# Altium schematic sheet 04_STM32_MCU.SchDoc(04_STM32_MCU)
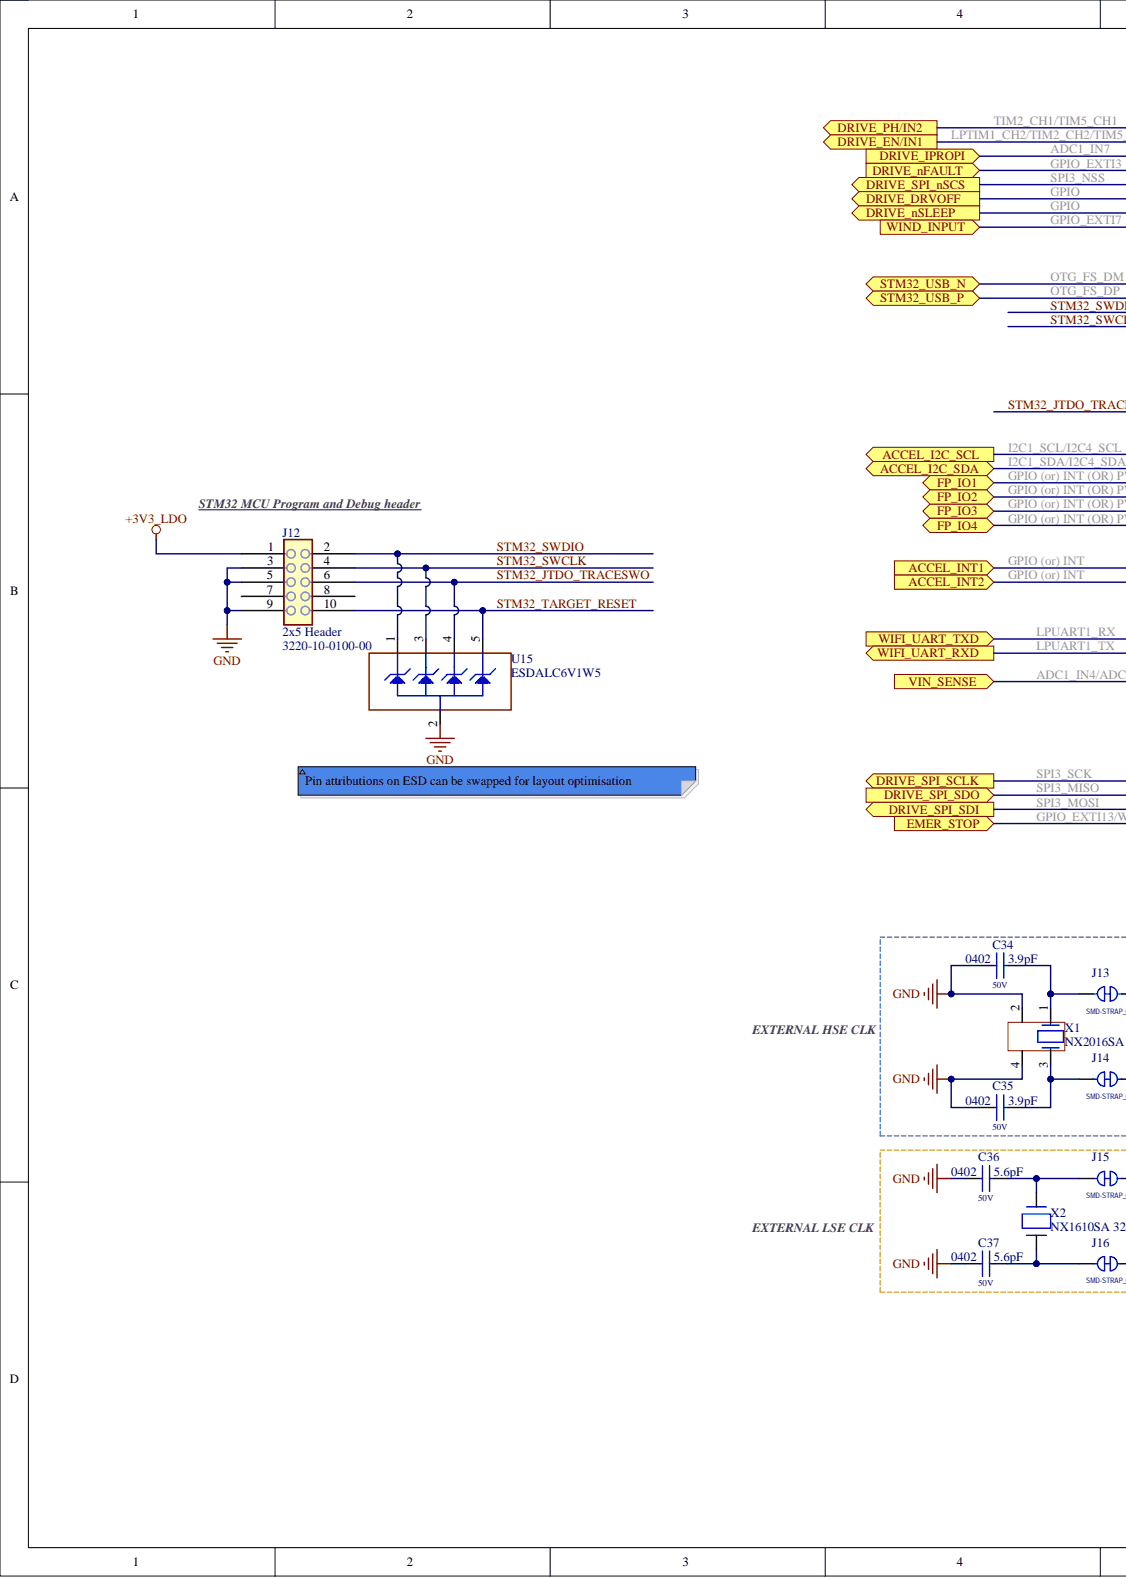
[diagram p0: 04_STM32_MCU.SchDoc, left]
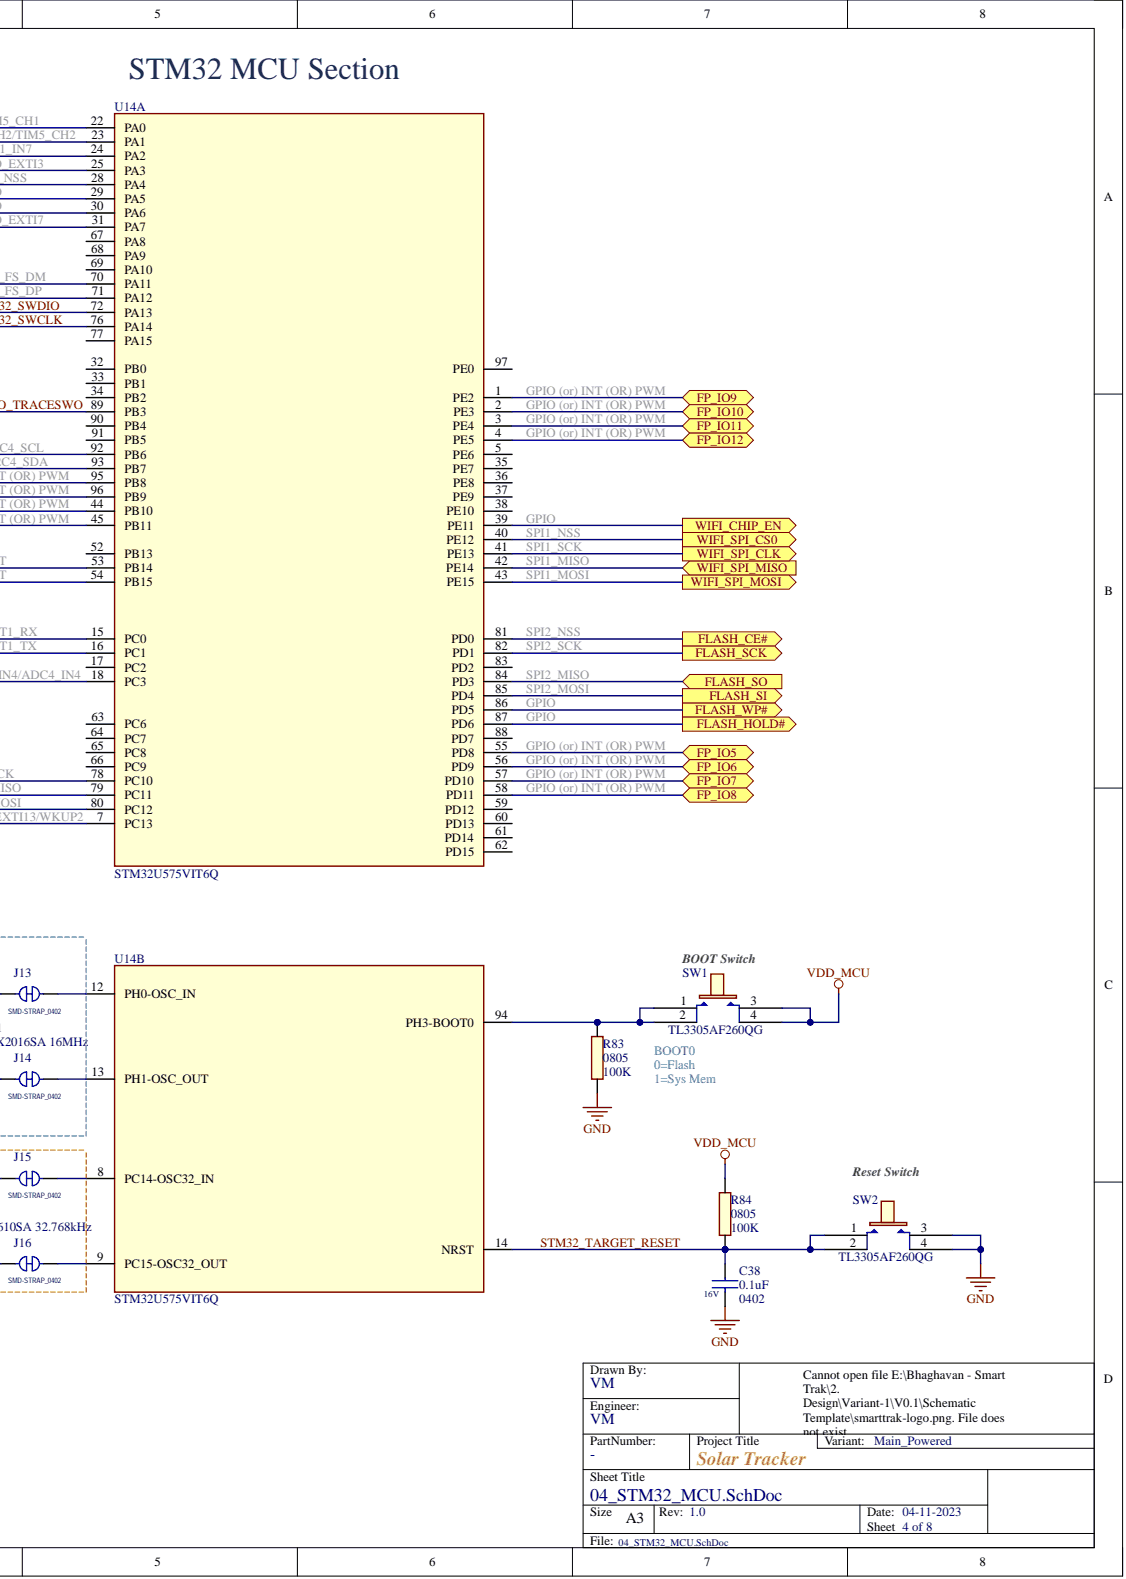
[diagram p1: 04_STM32_MCU.SchDoc, right]

## Parts
C34  value 3.9pF  Note: 0402  Voltage - Rated: 50V  [C0402]  (hidden comment: CAP_3.9pF_0402_50V)
C35  value 3.9pF  Note: 0402  Voltage - Rated: 50V  [C0402]  (hidden comment: CAP_3.9pF_0402_50V)
C36  value 5.6pF  Note: 0402  Voltage - Rated: 50V  [C0402]  (hidden comment: CAP_5.6pF_0402_50V)
C37  value 5.6pF  Note: 0402  Voltage - Rated: 50V  [C0402]  (hidden comment: CAP_5.6pF_0402_50V)
C38  value 0.1uF  Note: 0402  Voltage - Rated: 16V  [C0402]  (hidden comment: CAP_0.1uF_0402_16V)
J12  value 3220-10-0100-00  Note: 2x5 Header  [CNC_3220-10-0100-00]  (hidden comment: SWD_HEADER_2x5_TH)
J13  SMD-STRAP_0402  [SMD-STRAP_0402]
J14  SMD-STRAP_0402  [SMD-STRAP_0402]
J15  SMD-STRAP_0402  [SMD-STRAP_0402]
J16  SMD-STRAP_0402  [SMD-STRAP_0402]
R83  value 100K  Note: 0805  [R0805]  (hidden comment: RES_100K_0805)
R84  value 100K  Note: 0805  [R0805]  (hidden comment: RES_100K_0805)
SW1  value TL3305AF260QG  MPN: TL3305AF260QG  [SW_TL3305AF260QG]  (hidden comment: PUSHBUTTON_TL3305AF260QG)
SW2  value TL3305AF260QG  MPN: TL3305AF260QG  [SW_TL3305AF260QG]  (hidden comment: PUSHBUTTON_TL3305AF260QG)
U14  STM32U575VIT6Q  [QFP50P1600X1600X160-100N]  (parts A,B)
U15  value ESDALC6V1W5  MPN: ESDALC6V1W5  [SOT65P210X110-5N]  (hidden comment: TVS_ESDALC6V1W5)
X1  value NX2016SA 16MHz  [XTAL200X160X50-4N]  (hidden comment: XTAL_4_PINS)
X2  value NX1610SA 32.768kHz  [XTAL160X100X50-2N]  (hidden comment: XTAL_2PINS_32.768KHz)

## Ports
ACCEL_I2C_SCL, ACCEL_I2C_SDA, ACCEL_INT1, ACCEL_INT2, DRIVE_DRVOFF, DRIVE_EN/IN1, DRIVE_IPROPI, DRIVE_nFAULT, DRIVE_nSLEEP, DRIVE_PH/IN2, DRIVE_SPI_nSCS, DRIVE_SPI_SCLK, DRIVE_SPI_SDI, DRIVE_SPI_SDO, EMER_STOP, FLASH_CE#, FLASH_HOLD#, FLASH_SCK, FLASH_SI, FLASH_SO, FLASH_WP#, FP_IO1, FP_IO2, FP_IO3, FP_IO4, FP_IO5, FP_IO6, FP_IO7, FP_IO8, FP_IO9, FP_IO10, FP_IO11, FP_IO12, STM32_USB_N, STM32_USB_P, VIN_SENSE, WIFI_CHIP_EN, WIFI_SPI_CLK, WIFI_SPI_CS0, WIFI_SPI_MISO, WIFI_SPI_MOSI, WIFI_UART_RXD, WIFI_UART_TXD, WIND_INPUT

## Nets
+3V3_LDO: J12.1
GND: C34.1 C35.1 C36.1 C37.1 C38.2 J12.3 J12.5 J12.9 R83.1 SW2.3 SW2.4 U15.2 X1.2 X1.4
VDD_MCU: R84.2 SW1.3 SW1.4
ACCEL_I2C_SCL: U14.92(PB6) ; port ACCEL_I2C_SCL
ACCEL_I2C_SDA: U14.93(PB7) ; port ACCEL_I2C_SDA
ACCEL_INT1: U14.53(PB14) ; port ACCEL_INT1
ACCEL_INT2: U14.54(PB15) ; port ACCEL_INT2
DRIVE_DRVOFF: U14.29(PA5) ; port DRIVE_DRVOFF
DRIVE_EN/IN1: U14.23(PA1) ; port DRIVE_EN/IN1
DRIVE_IPROPI: U14.24(PA2) ; port DRIVE_IPROPI
DRIVE_nFAULT: U14.25(PA3) ; port DRIVE_nFAULT
DRIVE_nSLEEP: U14.30(PA6) ; port DRIVE_nSLEEP
DRIVE_PH/IN2: U14.22(PA0) ; port DRIVE_PH/IN2
DRIVE_SPI_nSCS: U14.28(PA4) ; port DRIVE_SPI_nSCS
DRIVE_SPI_SCLK: U14.78(PC10) ; port DRIVE_SPI_SCLK
DRIVE_SPI_SDI: U14.80(PC12) ; port DRIVE_SPI_SDI
DRIVE_SPI_SDO: U14.79(PC11) ; port DRIVE_SPI_SDO
EMER_STOP: U14.7(PC13) ; port EMER_STOP
FLASH_CE#: U14.81(PD0) ; port FLASH_CE#
FLASH_HOLD#: U14.87(PD6) ; port FLASH_HOLD#
FLASH_SCK: U14.82(PD1) ; port FLASH_SCK
FLASH_SI: U14.85(PD4) ; port FLASH_SI
FLASH_SO: U14.84(PD3) ; port FLASH_SO
FLASH_WP#: U14.86(PD5) ; port FLASH_WP#
FP_IO1: U14.95(PB8) ; port FP_IO1
FP_IO2: U14.96(PB9) ; port FP_IO2
FP_IO3: U14.44(PB10) ; port FP_IO3
FP_IO4: U14.45(PB11) ; port FP_IO4
FP_IO5: U14.55(PD8) ; port FP_IO5
FP_IO6: U14.56(PD9) ; port FP_IO6
FP_IO7: U14.57(PD10) ; port FP_IO7
FP_IO8: U14.58(PD11) ; port FP_IO8
FP_IO9: U14.1(PE2) ; port FP_IO9
FP_IO10: U14.2(PE3) ; port FP_IO10
FP_IO11: U14.3(PE4) ; port FP_IO11
FP_IO12: U14.4(PE5) ; port FP_IO12
STM32_JTDO_TRACESWO: J12.6 U14.89(PB3) U15.4
STM32_SWCLK: J12.4 U14.76(PA14) U15.3
STM32_SWDIO: J12.2 U14.72(PA13) U15.1
STM32_TARGET_RESET: C38.1 J12.10 R84.1 SW2.1 SW2.2 U14.14(NRST) U15.5
STM32_USB_N: U14.70(PA11) ; port STM32_USB_N
STM32_USB_P: U14.71(PA12) ; port STM32_USB_P
VIN_SENSE: U14.18(PC3) ; port VIN_SENSE
WIFI_CHIP_EN: U14.39(PE11) ; port WIFI_CHIP_EN
WIFI_SPI_CLK: U14.41(PE13) ; port WIFI_SPI_CLK
WIFI_SPI_CS0: U14.40(PE12) ; port WIFI_SPI_CS0
WIFI_SPI_MISO: U14.42(PE14) ; port WIFI_SPI_MISO
WIFI_SPI_MOSI: U14.43(PE15) ; port WIFI_SPI_MOSI
WIFI_UART_RXD: U14.16(PC1) ; port WIFI_UART_RXD
WIFI_UART_TXD: U14.15(PC0) ; port WIFI_UART_TXD
WIND_INPUT: U14.31(PA7) ; port WIND_INPUT
NetC34_2: C34.2 J13.2 X1.1
NetC35_2: C35.2 J14.2 X1.3
NetC36_2: C36.2 J15.2 X2.2
NetC37_2: C37.2 J16.2 X2.1
NetJ13_1: J13.1 U14.12(PH0-OSC_IN)
NetJ14_1: J14.1 U14.13(PH1-OSC_OUT)
NetJ15_1: J15.1 U14.8(PC14-OSC32_IN)
NetJ16_1: J16.1 U14.9(PC15-OSC32_OUT)
NetR83_2: R83.2 SW1.1 SW1.2 U14.94(PH3-BOOT0)

Not connected: J12 7 8; U14 5(PE6) 17(PC2) 32(PB0) 33(PB1) 34(PB2) 35(PE7) 36(PE8) 37(PE9) 38(PE10) 52(PB13) 59(PD12) 60(PD13) 61(PD14) 62(PD15) 63(PC6) 64(PC7) 65(PC8) 66(PC9) 67(PA8) 68(PA9) 69(PA10) 77(PA15) 83(PD2) 88(PD7) 90(PB4) 91(PB5) 97(PE0)

## Library data of the parts
C34 C35 = CAP_3.9pF_0402_50V: CAP CER 3.9PF 50V C0G/NPO 0402; Manufacturer: Yageo; MPN: CC0402CRNPO9BN3R9; Component Kind: Standard; Library Name: Project.SchLib
C36 C37 = CAP_5.6pF_0402_50V: CAP CER 5.6PF 50V C0G/NPO 0402; Manufacturer: Yageo; MPN: CC0402BRNPO9BN5R6; Component Kind: Standard; Library Name: Project.SchLib
C38 = CAP_0.1uF_0402_16V: CAP CER 0.1UF 16V X7R 0402; Manufacturer: Yageo; MPN: CC0402KRX7R7BB104; Component Kind: Standard; Library Name: Project.SchLib
J12 = SWD_HEADER_2x5_TH: CONN HEADER VERT 10POS 1.27MM; Manufacturer: CNC Tech; MPN: 3220-10-0100-00; Value: 3220-10-0100-00; Component Kind: Standard; Library Name: Project.SchLib
J13-J16 = SMD-STRAP_0402: Default closed SMD strap, 0402 size; Component Kind: Net Tie; Library Name: Project.SchLib; Manufacturer: -; MPN: -; Note: -; Value: SMD-STRAP_0402
R83 R84 = RES_100K_0805: RES SMD 100K OHM 5% 1/2W 0805; Manufacturer: Panasonic; MPN: ERJ-P06J104V; Component Kind: Standard; Library Name: Project.SchLib
SW1 SW2 = PUSHBUTTON_TL3305AF260QG: SWITCH TACTILE SPST-NO 50MA 12V; Manufacturer: E-Switch; Note: SMD Tactile Switch; Component Kind: Standard; Library Name: Project.SchLib
U14 = STM32U575VIT6Q: Ultra-low-power with FPU Arm Cortex-M33 with Trust Zone, MCU 160 MHz with 2Mbytes of Flash memory; Manufacturer: STMicroelectronics; Component Kind: Standard; Library Name: Project.SchLib; MPN: STM32U575VIT6Q; Value: STM32U575VIT6Q
U15 = TVS_ESDALC6V1W5: TVS DIODE 3VWM SOT323-5; Manufacturer: STMicroelectronics; Component Kind: Standard; ComponentLink1Description: Datasheet; ComponentLink1URL: http://tunmcdsvn.tun.st.com/Component_Datasheet_Library/Trunk/Diodes/STM_ESD_ESDALC6V1W5; Library Name: Project.SchLib
X1 = XTAL_4PINS_16MHz: CRYSTAL 16.0000MHZ 8PF SMD; Manufacturer: NDK; MPN: NX2016SA 16 MHz EXS00A-CS07826; Value: NX2016SA 16MHz; Component Kind: Standard; Library Name: Project.SchLib
X2 = XTAL_2PINS_32.768KHz: CRYSTAL 32.7680KHZ 6PF SMD; Manufacturer: NDK; MPN: NX1610SA-32.768KHZ-EXS00A-MU00658; Value: NX1610SA 32.768kHz; Component Kind: Standard; ComponentLink1Description: Part_Information; ComponentLink1URL: http://tunmcdsvn.tun.st.com/Component_Datasheet_Library/Trunk/Crystal_Osc/NDK_NX1610SE 32.768KHz EXS00A-MU01499; Library Name: Project.SchLib
